# Altium schematic sheet frm-voltrod_main.SchDoc
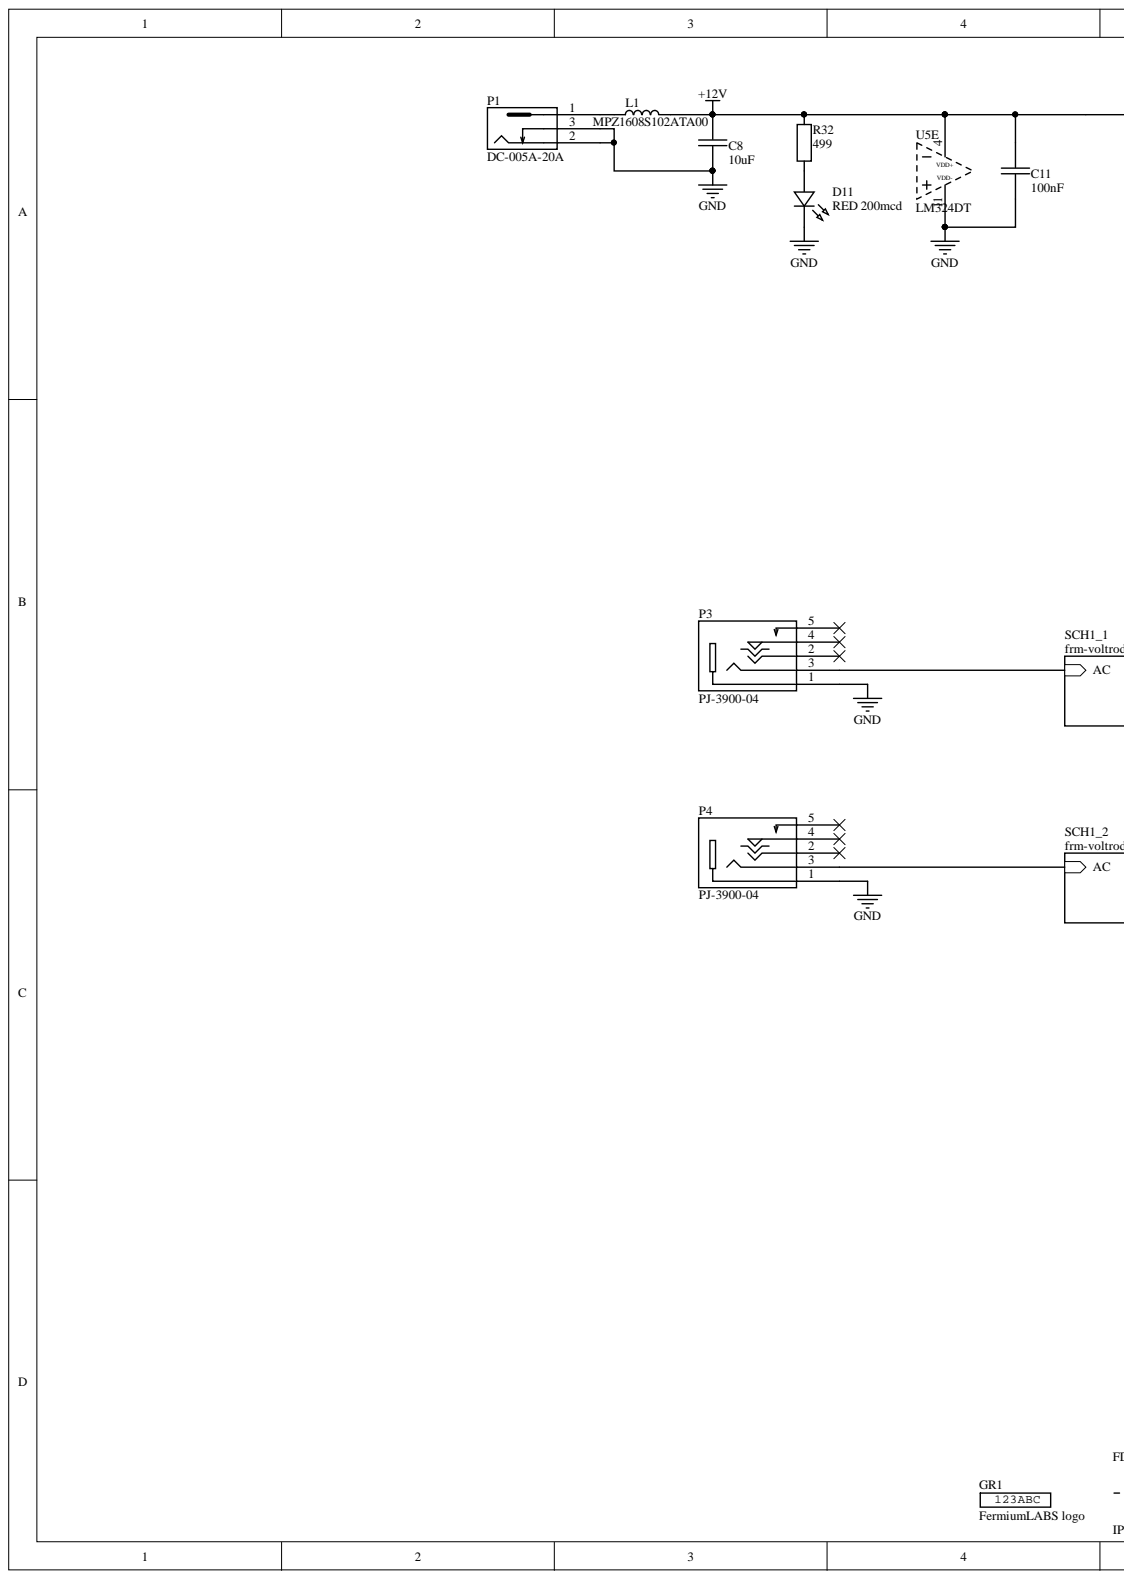
[diagram p0: frm-voltrod_main.SchDoc, left]
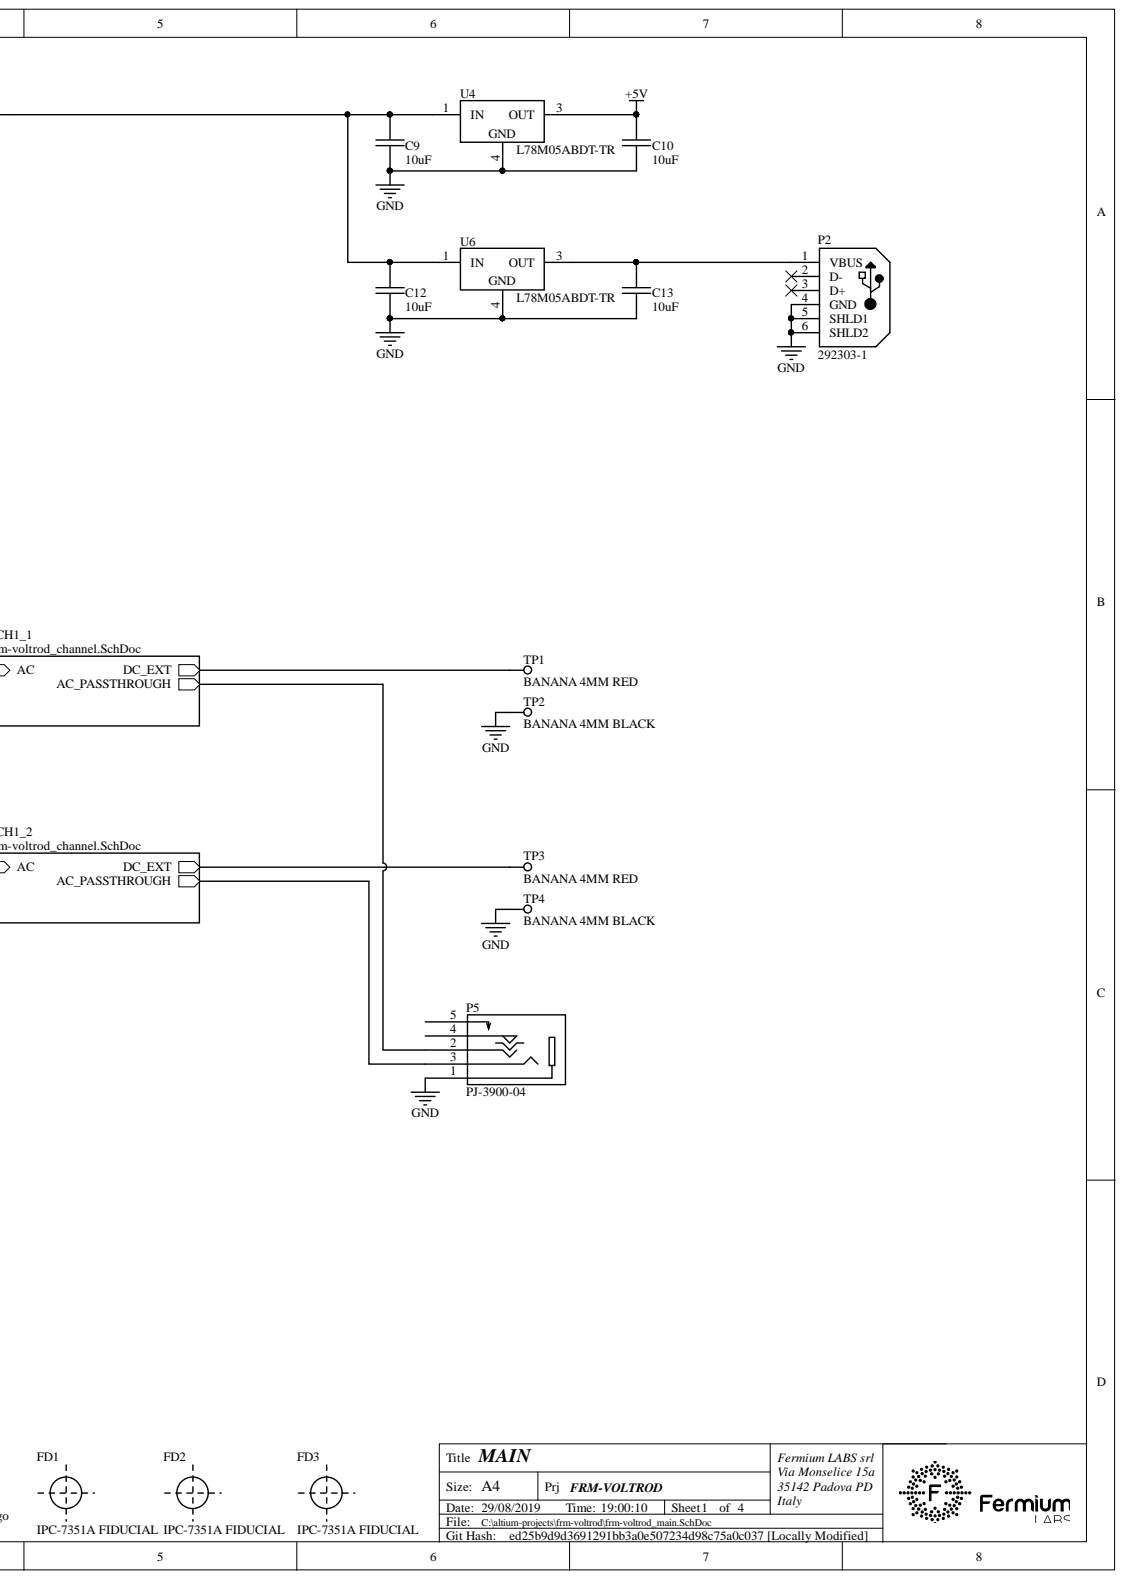
[diagram p1: frm-voltrod_main.SchDoc, right]

## Parts
C8  10uF  [CAP 0805_2012]
C9  10uF  [CAP 0805_2012]
C10  10uF  [CAP 0805_2012]
C11  100nF  [CAP 0603_1608]
C12  10uF  [CAP 0805_2012]
C13  10uF  [CAP 0805_2012]
D11  RED 200mcd  [ED49B4F6-595F-4D62-96BB-D7184CAA9B94]
FD1  IPC-7351A FIDUCIAL  [FIDUCIAL-IPC-7351A]  (no BOM)
FD2  IPC-7351A FIDUCIAL  [FIDUCIAL-IPC-7351A]  (no BOM)
FD3  IPC-7351A FIDUCIAL  [FIDUCIAL-IPC-7351A]  (no BOM)
GR1  FermiumLABS logo  [5394DE47-A0E8-4329-8464-7791B7C19310]  (no BOM)
L1  MPZ1608S102ATA00  [FER 0603_1608]
P1  DC-005A-20A  [F0972D8A-C5C0-4A84-836D-A9666EE65C2D]
P2  292303-1  [8A51F738-712D-4EE5-9D6B-BB16603F834E]
P3  PJ-3900-04  [7EF2B92C-0FC9-4C85-B662-5CEC65BFCCC4]
P4  PJ-3900-04  [7EF2B92C-0FC9-4C85-B662-5CEC65BFCCC4]
P5  PJ-3900-04  [7EF2B92C-0FC9-4C85-B662-5CEC65BFCCC4]
R32  499  [RES 1206_3216]
TP1  BANANA 4MM RED  (no BOM)
TP2  BANANA 4MM BLACK  (no BOM)
TP3  BANANA 4MM RED  (no BOM)
TP4  BANANA 4MM BLACK  (no BOM)
U4  L78M05ABDT-TR  [4BDA507D-BB70-4009-B963-85AD90086B51]
U5  LM324DT  [34355DA5-B1DA-47C2-A88A-ECF82120A4F7]  (part E)
U6  L78M05ABDT-TR  [4BDA507D-BB70-4009-B963-85AD90086B51]

## Nets
+5V: C10.1 U4.3
+12V: C8.1 C9.1 C11.1 C12.1 L1.2 R32.2 U4.1 U5.4 U6.1
GND: C8.2 C9.2 C10.2 C11.2 C12.2 C13.2 D11.1 P1.2 P1.3 P2.4(GND) P2.5(SHLD1) P2.6(SHLD2) P3.1 P4.1 P5.1 TP2.1 TP4.1 U4.4 U5.11 U6.4
NetC13_1: C13.1 P2.1(VBUS) U6.3
NetD11_2: D11.2 R32.1
NetL1_1: L1.1 P1.1
NetP2_2: P2.2(D-)
NetP2_3: P2.3(D+)
NetP3_2: P3.2
NetP3_3: P3.3
NetP3_4: P3.4
NetP3_5: P3.5
NetP4_2: P4.2
NetP4_3: P4.3
NetP4_4: P4.4
NetP4_5: P4.5
NetP5_2: P5.2
NetP5_3: P5.3
NetP5_4: P5.4
NetP5_5: P5.5
NetTP1_1: TP1.1
NetTP3_1: TP3.1

## Library data of the parts
C8-C10 C12 C13 = 1ffbe5a9-0884-4740-bdad-20a6a98400e6: CAP SMD MLCC 10uF 25V 0805 X5R; Manufacturer 1: Samsung Electro-Mechanics; Manufacturer Part Number 1: CL21A106KAYNNNE; Database Table Name: public.lateraldb; id: 14779; S 1: LCSC; SPN 1: C84416; SPN 2: C15850; Component Kind: Standard; ComponentLink1Description: Datasheet; ComponentLink1URL: http://localhost:8080/static/files/Samsung-Electro-Mechanics-CL21A106KAYNNNE_C158502019-03-10_213827.610739.pdf?Samsung-Electro-Mechanics-CL21A106KAYNNNE_C15850.pdf; Manufacturer 2: Murata; Manufacturer Part Number 2: GRM21BR61E106KA73L; Part Number: 1ffbe5a9-0884-4740-bdad-20a6a98400e6; S 2: LCSC; Value: 10uF; Library Name: frmdblib.DbLib
C11 = 02841f84-ac15-41b5-9e17-915f8f067828: CAP SMD MLCC 100nF 25V 0603 X7R; Manufacturer 1: Samsung Electro-Mechanics; Manufacturer Part Number 1: CL10B104KA8NNNC; Database Table Name: public.lateraldb; id: 14784; S 1: LCSC; SPN 1: C1590; Component Kind: Standard; ComponentLink1Description: Datasheet; ComponentLink1URL: http://localhost:8080/static/files/Samsung-Electro-Mechanics-CL10B104KA8NNNC_C15902019-03-10_215548.826887.pdf?Samsung-Electro-Mechanics-CL10B104KA8NNNC_C1590.pdf; Part Number: 02841f84-ac15-41b5-9e17-915f8f067828; Value: 100nF; Library Name: frmdblib.DbLib
D11 = c65ae3d2-9539-4634-8979-616a331b7494: DIODE LED THT 5MM RED 200mcd; Manufacturer 1: Everlight; Manufacturer Part Number 1: 333-2SURD/S530-A3-L; Database Table Name: public.lateraldb; id: 14851; S 1: LCSC; SPN 1: C99758; Component Kind: Standard; ComponentLink1Description: Datasheet; ComponentLink1URL: http://localhost:8080/static/files/Everlight-Elec-333-2SURD-S530-A3-L_C997582019-08-18_181624.501044.pdf?Everlight-Elec-333-2SURD-S530-A3-L_C99758.pdf; Part Number: c65ae3d2-9539-4634-8979-616a331b7494; Value: RED 200mcd; Library Name: frmdblib.DbLib
FD1-FD3 = 19da4612-eb95-43bb-9984-553a52b2a224: GRAPHICS FIDUCIAL IPC-7351A; Database Table Name: public.lateraldb; id: 14800; Part Number: 19da4612-eb95-43bb-9984-553a52b2a224; Library Name: frmdblib.DbLib
GR1 = 50f8ea88-0034-49fe-a263-d8e97bc35e2f: GRAPHICS LOGO FERMIUM LABS SRL; Database Table Name: public.lateraldb; id: 14799; Part Number: 50f8ea88-0034-49fe-a263-d8e97bc35e2f; Library Name: frmdblib.DbLib
L1 = a4b2e015-bf25-47b8-954c-a5b040744a3a: FER SMD CHIP 0603 800mA 1K@100MHz 300mOhm; Manufacturer 1: TDK; Database Table Name: public.lateraldb; id: 14850; S 1: LCSC; SPN 1: C76814; Component Kind: Standard; ComponentLink1Description: Datasheet; ComponentLink1URL: http://localhost:8080/static/files/TDK-MPZ1608S102ATA00_C768142019-08-18_021255.210641.pdf?TDK-MPZ1608S102ATA00_C76814.pdf; Manufacturer Part Number 1: MPZ1608S102ATA00; Part Number: a4b2e015-bf25-47b8-954c-a5b040744a3a; Library Name: frmdblib.DbLib
P1 = b53db51a-1725-412b-9039-fa7a45c7c438: CONN THT DC JACK 0.5A 30VDC 5Kcycles; Manufacturer 1: HRO Electronics; Database Table Name: public.lateraldb; id: 14780; S 1: LCSC; SPN 1: C191049; Component Kind: Standard; ComponentLink1Description: Datasheet; ComponentLink1URL: http://localhost:8080/static/files/Korean-Hroparts-Elec-DC-005A-20A_C191049_22019-03-10_214231.486078.pdf?Korean-Hroparts-Elec-DC-005A-20A_C191049 (2).pdf; Manufacturer Part Number 1: DC-005A-20A; Part Number: b53db51a-1725-412b-9039-fa7a45c7c438; Library Name: frmdblib.DbLib
P2 = 9ff2a560-e541-4da0-adda-013cc9c65511: CONN THT USB TYPE-A HIGH RELIABILITY; Manufacturer 1: TE Connectivity; Database Table Name: public.lateraldb; id: 14855; S 1: LCSC; SPN 1: C86461; Component Kind: Standard; ComponentLink1Description: Datasheet; ComponentLink1URL: http://localhost:8080/static/files/TE_Connectivity-292303-1-datasheet2019-08-25_172711.777436.pdf?TE_Connectivity-292303-1-datasheet.pdf; Manufacturer Part Number 1: 292303-1; Part Number: 9ff2a560-e541-4da0-adda-013cc9c65511; Library Name: frmdblib.DbLib
P3-P5 = 80507a24-5d7c-4cf5-953e-f54832d54564: CONN THT 3.5mm AUDIO STEREO AUX SWITCH; Manufacturer 1: HRO Electronics; Database Table Name: public.lateraldb; id: 14793; S 1: LCSC; SPN 1: C151839; Component Kind: Standard; ComponentLink1Description: Datasheet; ComponentLink1URL: http://localhost:8080/static/files/Korean-Hroparts-Elec-PJ-3900-04_C1518392019-04-10_231144.687617.pdf?Korean-Hroparts-Elec-PJ-3900-04_C151839.pdf; Manufacturer Part Number 1: PJ-3900-04; Part Number: 80507a24-5d7c-4cf5-953e-f54832d54564; Library Name: frmdblib.DbLib
R32 = c8bf8de8-3430-48dd-94ac-a027709c0c4f: RES SMD 499 OHM 1% 1/4W 1206; Manufacturer 1: Yageo; Manufacturer Part Number 1: RC1206FR-07499RL; Database Table Name: public.lateraldb; id: 14010; S 1: LCSC; SPN 1: C137267; Component Kind: Standard; Part Number: c8bf8de8-3430-48dd-94ac-a027709c0c4f; Value: 499; Library Name: frmdblib.DbLib
TP1 TP3 = 3b84d3c9-1de5-4004-b768-3958a778153b: CONN BANANA SOCKET 4MM RED; Manufacturer 1: Changzhou Amass Elec; Manufacturer Part Number 1: 24.247.1; Database Table Name: public.lateraldb; id: 14826; S 1: LCSC; SPN 1: C106273; ComponentLink1Description: Datasheet; ComponentLink1URL: http://localhost:8080/static/files/Changzhou-Amass-Elec-24-247-1_C1062732019-08-09_175257.693472.pdf?Changzhou-Amass-Elec-24-247-1_C106273.pdf; Part Number: 3b84d3c9-1de5-4004-b768-3958a778153b; Library Name: frmdblib.DbLib
TP2 TP4 = 80ef162c-aaff-440a-80ad-5900ce15f9c1: CONN BANANA SOCKET 4MM BLACK; Manufacturer 1: Changzhou Amass Elec; Manufacturer Part Number 1: 24.247.2; Database Table Name: public.lateraldb; id: 14827; S 1: LCSC; SPN 1: C106272; Part Number: 80ef162c-aaff-440a-80ad-5900ce15f9c1; Library Name: frmdblib.DbLib
U4 U6 = 446536aa-1bdd-4764-8cae-49f4e0c126ff: IC SMD LINEAR VOLTAGE REGULATOR 5V 500mA DPAK/TO-252; Manufacturer 1: ST Microelectronics; Database Table Name: public.lateraldb; id: 14848; S 1: LCSC; SPN 1: C58069; Component Kind: Standard; ComponentLink1Description: Datasheet; ComponentLink1URL: http://localhost:8080/static/files/STMicroelectronics-L78M05ABDT-TR_C580692019-08-17_184424.026041.pdf?STMicroelectronics-L78M05ABDT-TR_C58069.pdf; Manufacturer Part Number 1: L78M05ABDT-TR; Part Number: 446536aa-1bdd-4764-8cae-49f4e0c126ff; Library Name: frmdblib.DbLib
U5 = 21a85167-c328-42f7-a52e-365842c6dad3: IC SMD OPAMP LM324DT SO-14; Manufacturer 1: ST Microelectronics; Database Table Name: public.lateraldb; id: 14792; S 1: LCSC; SPN 1: C71035; Component Kind: Standard; ComponentLink1Description: Datasheet; ComponentLink1URL: http://localhost:8080/static/files/STMicroelectronics-LM324DT_C710352019-04-06_191500.254110.pdf?STMicroelectronics-LM324DT_C71035.pdf; Manufacturer Part Number 1: LM324DT; Part Number: 21a85167-c328-42f7-a52e-365842c6dad3; Library Name: frmdblib.DbLib
